# Altium schematic sheet DigitalIO.SchDoc
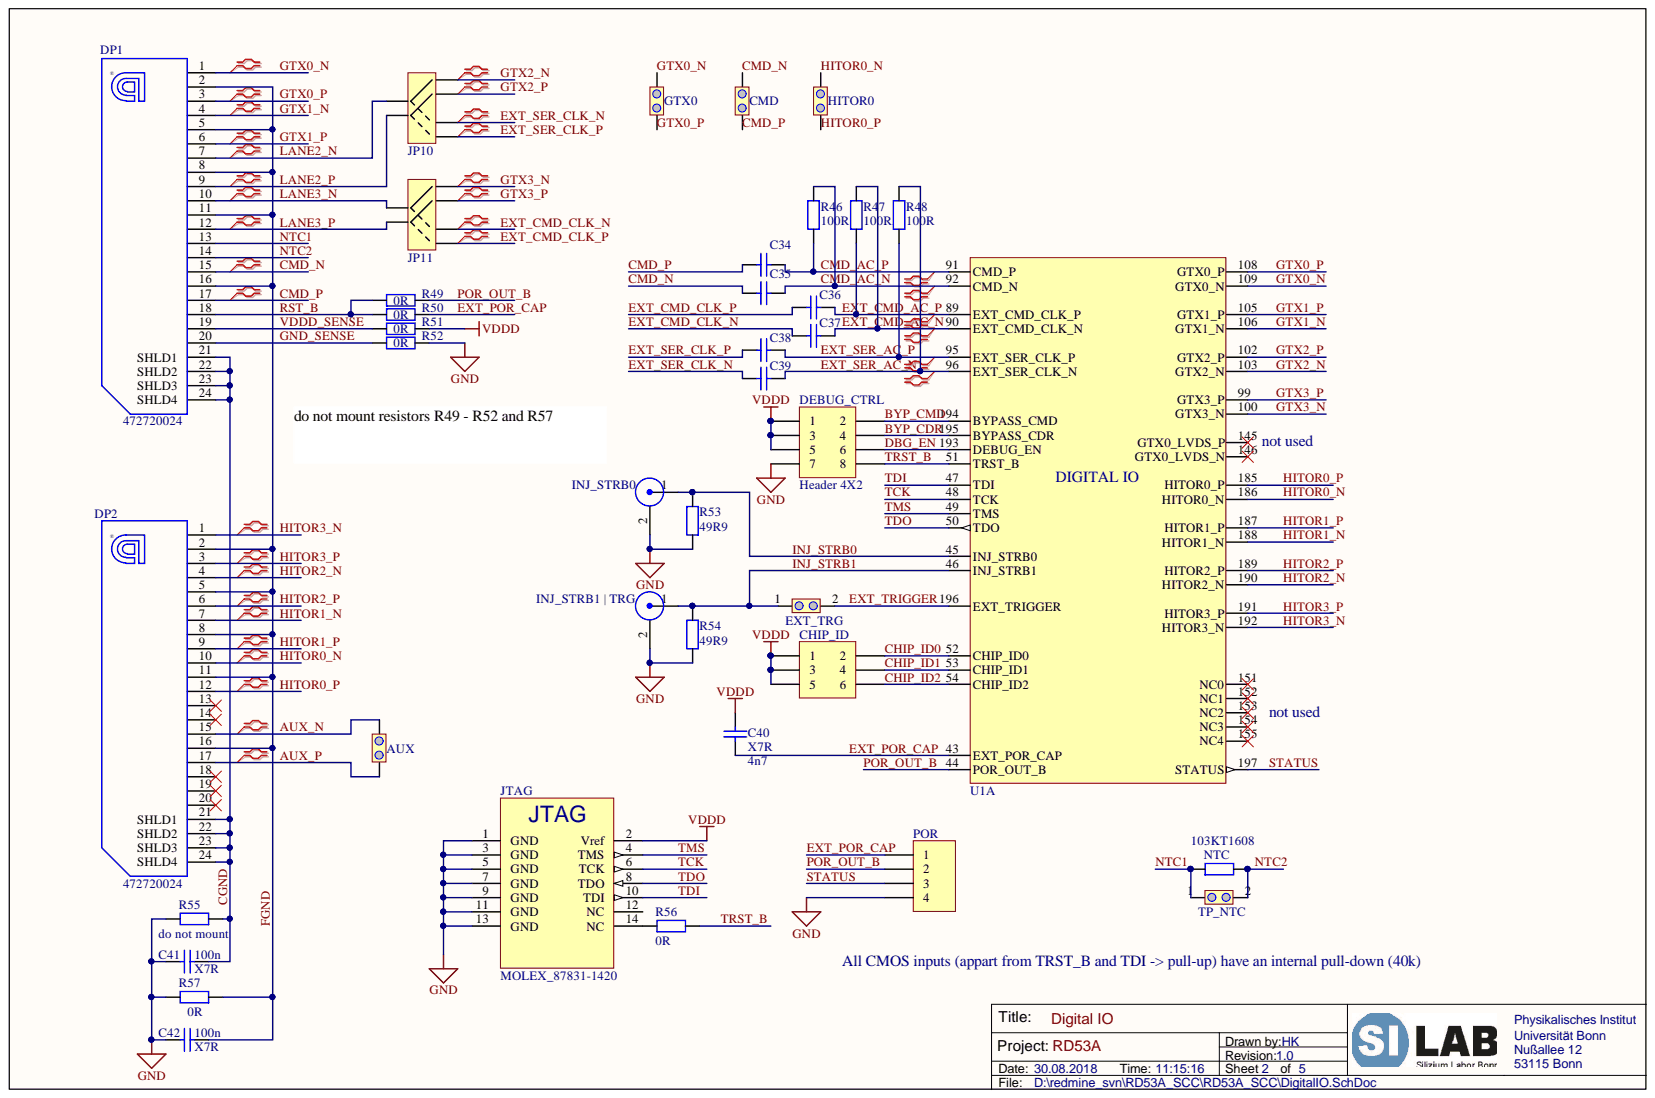
[diagram p0: DigitalIO.SchDoc]

## Parts
AUX  -  [CON 1x2 std]  (value not drawn)
C34  X7R  [C0402_imp_L]  (value not drawn)
C35  X7R  [C0402_imp_L]  (value not drawn)
C36  X7R  [C0402_imp_L]  (value not drawn)
C37  X7R  [C0402_imp_L]  (value not drawn)
C38  X7R  [C0402_imp_L]  (value not drawn)
C39  X7R  [C0402_imp_L]  (value not drawn)
C40  X7R  value 4n7  [C0402_imp_L]
C41  X7R  value 100n  [C0603_imp_N]
C42  X7R  value 100n  [C0603_imp_N]
CHIP_ID  Header 3X2  [HDR2X3]  (value not drawn)
CMD  -  [CON 1x2 std]  (value not drawn)
DEBUG_CTRL  Header 4X2  [HDR2X4]
DP1  472720024  [MOLX-472720024_V]
DP2  472720024  [MOLX-472720024_V]
EXT_TRG  -  [CON 1x2 std]  (value not drawn)
GTX0  -  [CON 1x2 std]  (value not drawn)
HITOR0  -  [CON 1x2 std]  (value not drawn)
INJ_STRB0  -  [LEMO horiz.]  (value not drawn)
INJ_STRB1_|_TRG  -  [LEMO horiz.]  (value not drawn)
JP10  Diff. Jumper  [Differential lane jumper]  (value not drawn)
JP11  Diff. Jumper  [Differential lane jumper]  (value not drawn)
JTAG  MOLEX_87831-1420  [Header 2x7; JTAG; Slave Serial;]
NTC  value 103KT1608  [R0402_imp_N]  (hidden comment: R?)
POR  (no value)  [HDR1X4]
R46  R  value 100R  [R0402_imp_N]
R47  R  value 100R  [R0402_imp_N]
R48  R  value 100R  [R0402_imp_N]
R49  R  value 0R  [R0402_imp_N]
R50  R  value 0R  [R0402_imp_N]
R51  R  value 0R  [R0402_imp_N]
R52  R  value 0R  [R0402_imp_N]
R53  R  value 49R9  [R0402_imp_N]
R54  R  value 49R9  [R0402_imp_N]
R55  R  value do not mount  [R0603_imp_N]
R56  R  value 0R  [R0402_imp_N]
R57  R  value 0R  [R0603_imp_N]
TP_NTC  -  [CON 1x2 std]  (value not drawn)
U1  RD53A  [RD53A Chip]  (value not drawn)  (part A)

## Nets
GND: C41.2 C42.2 DEBUG_CTRL.7 INJ_STRB0.2 INJ_STRB1_|_TRG.2 JTAG.1(GND) JTAG.3(GND) JTAG.5(GND) JTAG.7(GND) JTAG.9(GND) JTAG.11(GND) JTAG.13(GND) POR.4 R52.2 R53.1 R54.1 R55.2 R57.2
VDDD: C40.1 CHIP_ID.1 CHIP_ID.3 CHIP_ID.5 DEBUG_CTRL.1 DEBUG_CTRL.3 DEBUG_CTRL.5 JTAG.2(Vref) R51.2
AUX_N: AUX.2 DP2.15
AUX_P: AUX.1 DP2.17
BYP_CDR: DEBUG_CTRL.4 U1.195(BYPASS_CDR)
BYP_CMD: DEBUG_CTRL.2 U1.194(BYPASS_CMD)
CGND: C41.1 DP1.21(SHLD1) DP1.22(SHLD2) DP1.23(SHLD3) DP1.24(SHLD4) DP2.21(SHLD1) DP2.22(SHLD2) DP2.23(SHLD3) DP2.24(SHLD4) R55.1
CHIP_ID0: CHIP_ID.2 U1.52(CHIP_ID0)
CHIP_ID1: CHIP_ID.4 U1.53(CHIP_ID1)
CHIP_ID2: CHIP_ID.6 U1.54(CHIP_ID2)
CMD_AC_N: C35.2 R46.1 U1.92(CMD_N)
CMD_AC_P: C34.2 R46.2 U1.91(CMD_P)
CMD_N: C35.1 CMD.2 DP1.15
CMD_P: C34.1 CMD.1 DP1.17
DBG_EN: DEBUG_CTRL.6 U1.193(DEBUG_EN)
EXT_CMD_AC_N: C37.2 R47.1 U1.90(EXT_CMD_CLK_N)
EXT_CMD_AC_P: C36.2 R47.2 U1.89(EXT_CMD_CLK_P)
EXT_CMD_CLK_N: C37.1 JP11.5
EXT_CMD_CLK_P: C36.1 JP11.6
EXT_POR_CAP: C40.2 POR.1 R50.2 U1.43(EXT_POR_CAP)
EXT_SER_AC_N: C39.2 R48.2 U1.96(EXT_SER_CLK_N)
EXT_SER_AC_P: C38.2 R48.1 U1.95(EXT_SER_CLK_P)
EXT_SER_CLK_N: C39.1 JP10.5
EXT_SER_CLK_P: C38.1 JP10.6
EXT_TRIGGER: EXT_TRG.2 U1.196(EXT_TRIGGER)
FGND: C42.1 DP1.2 DP1.5 DP1.8 DP1.11 DP1.16 DP2.2 DP2.5 DP2.8 DP2.11 DP2.16 R57.1
GND_SENSE: DP1.20 R52.1
GTX0_N: DP1.1 GTX0.2 U1.109(GTX0_N)
GTX0_P: DP1.3 GTX0.1 U1.108(GTX0_P)
GTX1_N: DP1.4 U1.106(GTX1_N)
GTX1_P: DP1.6 U1.105(GTX1_P)
GTX2_N: JP10.3 U1.103(GTX2_N)
GTX2_P: JP10.4 U1.102(GTX2_P)
GTX3_N: JP11.3 U1.100(GTX3_N)
GTX3_P: JP11.4 U1.99(GTX3_P)
HITOR0_N: DP2.10 HITOR0.2 U1.186(HITOR0_N)
HITOR0_P: DP2.12 HITOR0.1 U1.185(HITOR0_P)
HITOR1_N: DP2.7 U1.188(HITOR1_N)
HITOR1_P: DP2.9 U1.187(HITOR1_P)
HITOR2_N: DP2.4 U1.190(HITOR2_N)
HITOR2_P: DP2.6 U1.189(HITOR2_P)
HITOR3_N: DP2.1 U1.192(HITOR3_N)
HITOR3_P: DP2.3 U1.191(HITOR3_P)
INJ_STRB0: INJ_STRB0.1 R53.2 U1.45(INJ_STRB0)
INJ_STRB1: EXT_TRG.1 INJ_STRB1_|_TRG.1 R54.2 U1.46(INJ_STRB1)
LANE2_N: DP1.7 JP10.1
LANE2_P: DP1.9 JP10.2
LANE3_N: DP1.10 JP11.1
LANE3_P: DP1.12 JP11.2
NTC1: DP1.13 NTC.1 TP_NTC.1
NTC2: DP1.14 NTC.2 TP_NTC.2
POR_OUT_B: POR.2 R49.2 U1.44(POR_OUT_B)
RST_B: DP1.18 R49.1 R50.1
STATUS: POR.3 U1.197(STATUS)
TCK: JTAG.6(TCK) U1.48(TCK)
TDI: JTAG.10(TDI) U1.47(TDI)
TDO: JTAG.8(TDO) U1.50(TDO)
TMS: JTAG.4(TMS) U1.49(TMS)
TRST_B: DEBUG_CTRL.8 R56.2 U1.51(TRST_B)
VDDD_SENSE: DP1.19 R51.1
NetJTAG_14: JTAG.14(NC) R56.1

Not connected: DP2 13 14 18 19 20; JTAG 12(NC); U1 145(GTX0_LVDS_P) 146(GTX0_LVDS_N) 151(NC0) 152(NC1) 153(NC2) 154(NC3) 155(NC4)

## Library data of the parts
AUX CMD EXT_TRG GTX0 HITOR0 TP_NTC = JUMPER 1X2S: Jumper, Solderbridge 1x2; Class: Connector; Comment_1: -; Component Kind: Standard; Constraints: -; HelpURL: -; LatestRevisionDate: 24-Sep-2008; PackageDescription: -; Type_1: -; Value: -; Library Name: Silab_Lib.IntLib; Publisher: Silab C.H.
C34-C39 = C: Capacitor; Class: Passive; Constraints: 6.3V; Type_1: SMD Chip Capacitor; Value: 100n; Comment_1: -; Component Kind: Standard; HelpURL: -; LatestRevisionDate: 27-Nov-2007; PackageDescription: -; Signal Integrity: Capacitor; Simulation: Capacitor; Library Name: Silab_Lib.IntLib; Publisher: Silab J.S.
C40-C42 = C: Capacitor; Class: Passive; Constraints: 6.3V; Type_1: SMD Chip Capacitor; Comment_1: -; Component Kind: Standard; HelpURL: -; LatestRevisionDate: 27-Nov-2007; PackageDescription: -; Signal Integrity: Capacitor; Simulation: Capacitor; Library Name: Silab_Lib.IntLib; Publisher: Silab J.S.
CHIP_ID = Header 3X2: Header, 3-Pin, Dual row; Component Kind: Standard; LatestRevisionDate: 17-Jul-2002; LatestRevisionNote: Re-released for DXP Platform.; Library Name: Miscellaneous Connectors.IntLib; Signal Integrity: Connector; Publisher: Altium Limited
DEBUG_CTRL = Header 4X2: Header, 4-Pin, Dual row; Component Kind: Standard; LatestRevisionDate: 17-Jul-2002; LatestRevisionNote: Re-released for DXP Platform.; Library Name: Miscellaneous Connectors.IntLib; Signal Integrity: Connector; Publisher: Altium Limited
DP1 DP2 = CMP-1704-00002-3: DisplayPort Connector, Pitch 0.5 mm, 1 x 20 Position, Height 6.65 mm, -20 to 85 degC, RoHS, Tape and Reel; Component Kind: Standard; ComponentLink1Description: Manufacturer URL; ComponentLink1URL: http://www.molex.com/; ComponentLink2Description: Datasheet; ComponentLink2URL: http://www.molex.com/pdm_docs/sd/472720024_sd.pdf; DatasheetVersion: Rev. F; Height: 6.65 mm; Length: 17.79 mm; Library Name: Altium Content Vault; Max Operating Temperature: 85 degC; Min Operating Temperature: -20 degC; Mount: Surface Mount; Mounting Technology: Surface Mount; PackageDescription: DisplayPort Connector, Pitch 0.5 mm, 1 x 20 Position, Height 6.65 mm; Package Quantity: 1000; Packaging: Tape and Reel; PartNumber: 472720024; Pins: 20; REACH SVHC: Unknown; RoHSCompliant: True; Width: 14.39 mm
INJ_STRB0 INJ_STRB1_|_TRG = LEMO coax: LEMO/BNC Buchse, aufrecht od. gewinkelt; Class: Connector; Comment_1: LEMO; Type_1: EPL.00.250.NTN; Component Kind: Standard; Constraints: -; HelpURL: -; LatestRevisionDate: 24-Sep-2008; PackageDescription: -; Value: -; Library Name: Silab_Lib.IntLib; Publisher: Silab C.H.
JTAG = XILINX JTAG CON: Molex 87831-1420; 14Pin; 2mm Pitch;; Class: Connector; Comment_1: Molex; Component Kind: Standard; Constraints: -; HelpURL: -; LatestRevisionDate: 24-Sep-2008; PackageDescription: -; Type_1: 87831-1420; Library Name: Silab_Lib.IntLib; Publisher: Silab C.H.
NTC R46-R57 = R: SMD Chip Resistor; Class: Passive; Constraints: 1%; Comment_1: -; Component Kind: Standard; HelpURL: -; LatestRevisionDate: 27-Nov-2007; PackageDescription: -; Signal Integrity: Resistor; Simulation: Resistor; Type_1: SMD Chip Resistor; Library Name: Silab_Lib.IntLib; Publisher: Silab J.S.
POR = Header 4: Header, 4-Pin; Component Kind: Standard; LatestRevisionDate: 17-Jul-2002; LatestRevisionNote: Re-released for DXP Platform.; Library Name: Miscellaneous Connectors.IntLib; Signal Integrity: Connector; Publisher: Altium Limited
JP10 JP11 = Diff. Jumper: Component Kind: Standard; Library Name: RD53A.SchLib
U1 = RD53A: Component Kind: Standard; Description: RD53A; Library Name: RD53A.SchLib
